# Altium schematic sheet 2- balenaFin HAT SCH.SchDoc
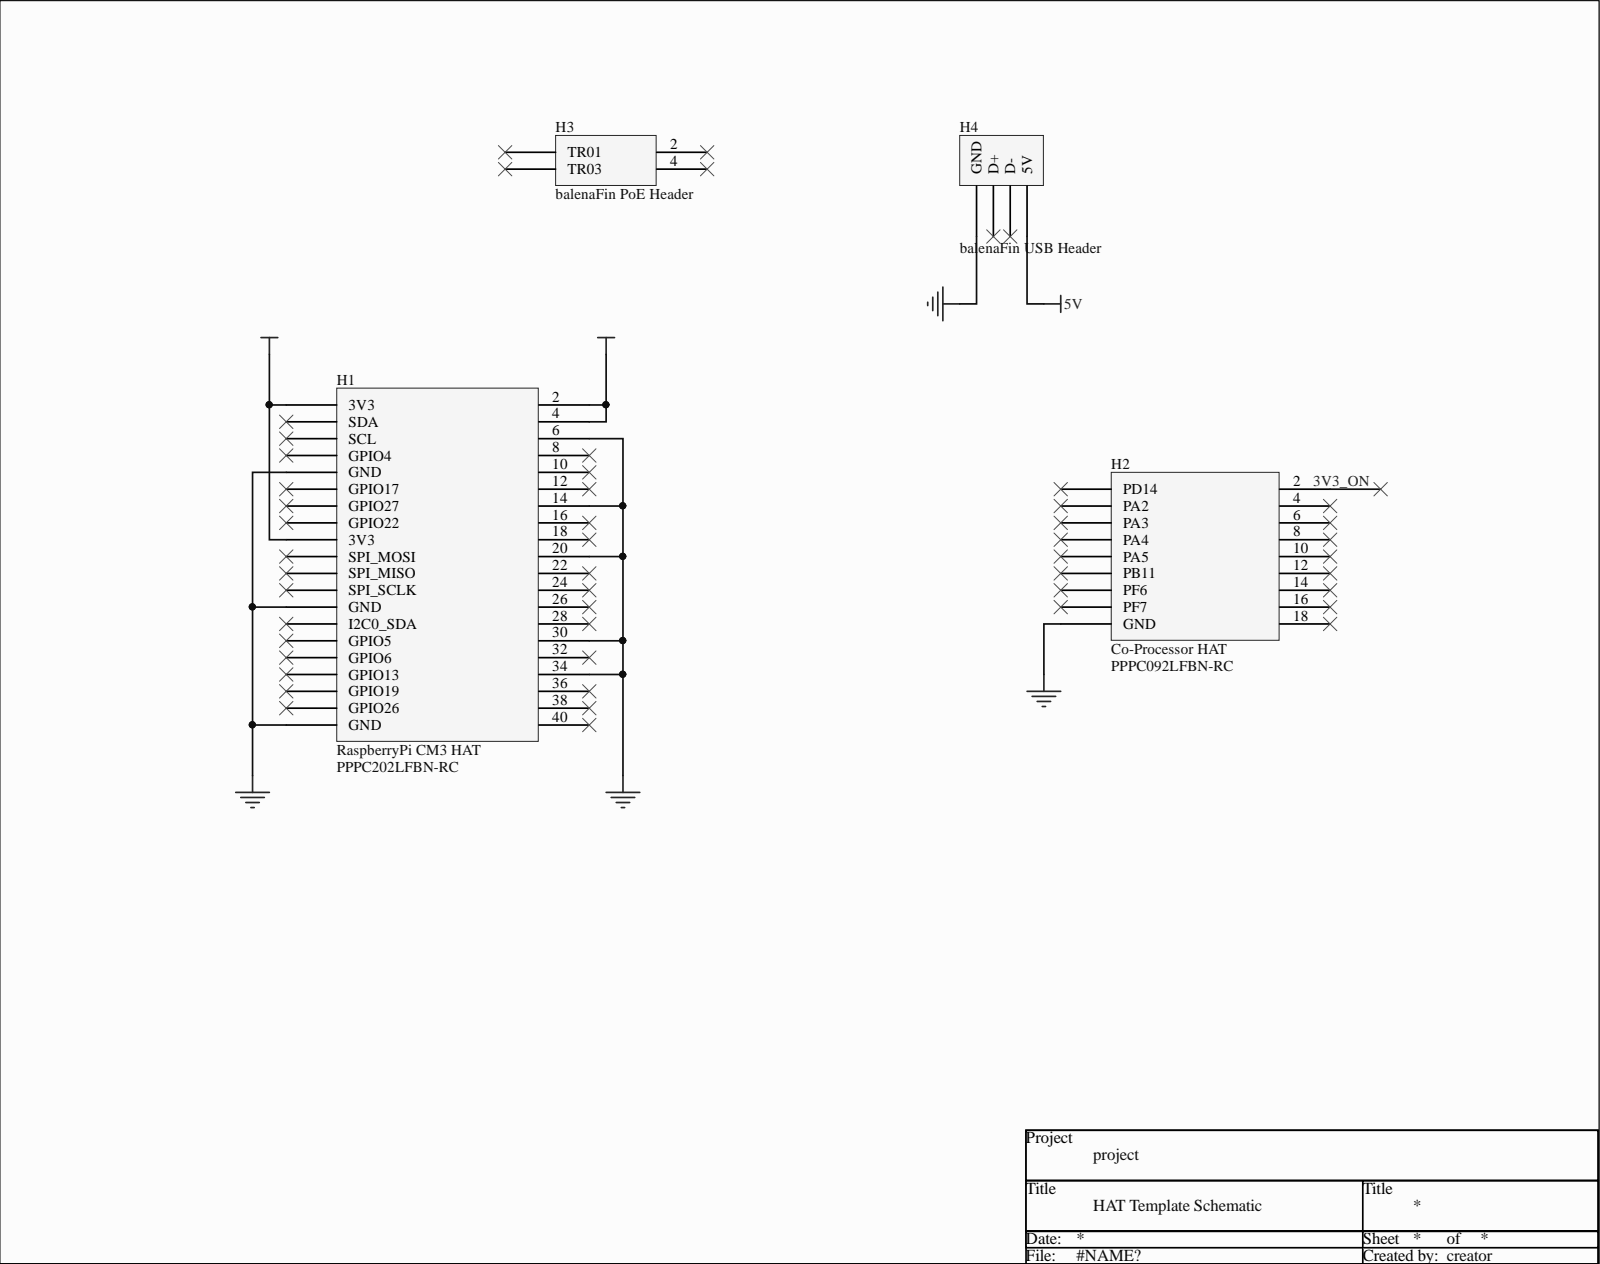
[diagram p0: 2- balenaFin HAT SCH.SchDoc]

## Parts
H1  RaspberryPi CM3 HAT  Manufacturer Part Number: PPPC202LFBN-RC  [HDR2x20_2mm54]
H2  Co-Processor HAT  Manufacturer Part Number: PPPC092LFBN-RC  [HDR2x9_2mm54]
H3  balenaFin PoE Header  [HDR2x2_2mm54]
H4  balenaFin USB Header  [HDR1x4_2mm54]

## Nets
3V3: H1.1(3V3) H1.17(3V3)
5V: H1.2 H1.4 H4.1(5V)
GND: H1.6 H1.9(GND) H1.14 H1.20 H1.25(GND) H1.30 H1.34 H1.39(GND) H2.17(GND) H4.4(GND)
3V3_ON:

Not connected: H1 3(SDA) 5(SCL) 7(GPIO4) 8 10 11(GPIO17) 12 13(GPIO27) 15(GPIO22) 16 18 19(SPI_MOSI) 21(SPI_MISO) 22 23(SPI_SCLK) 24 26 27(I2C0_SDA) 28 29(GPIO5) 31(GPIO6) 32 33(GPIO13) 35(GPIO19) 36 37(GPIO26) 38 40; H2 1(PD14) 2 3(PA2) 4 5(PA3) 6 7(PA4) 8 9(PA5) 10 11(PB11) 12 13(PF6) 14 15(PF7) 16 18; H3 1(TR01) 2 3(TR03) 4; H4 2(D-) 3(D+)

## Library data of the parts
H1 = CM3_Header: Raspberry Pi CM3 HAT Socket; Manufacturer: Sullins; Connector Type: Header,Socket; Number of Positions: 40; Pitch: 0.1inch; Color: Black; Component Kind: Standard; Contact Material: Bronze; Contact Plating: Gold; Height: 8.5mm; Housing Material: Plastic; Lead Free: Lead Free; Length: 51.3mm; Mount: Through Hole; Number of Rows: 2; Package Quantity: 1000; Packaging: Bulk; Row Spacing: 0.1inch; Stock 1: 0; Supplier 1: Future Electronics; Supplier Part Number 1: PPPC202LFBN-RC; Termination: Solder; Width: 5mm; Library Name: balenaPerf schlib.SchLib
H2 = COP_Header: balenaFin Co-Processor HAT Socket; Manufacturer: Sullins; Connector Type: Header,Socket; Number of Positions: 18; Pitch: 0.1inch; Color: Black; Component Kind: Standard; Contact Material: Bronze; Contact Plating: Gold; Height: 8.5mm; Housing Material: Plastic; Lead Free: Lead Free; Length: 23.4mm; Mount: Through Hole; Number of Rows: 2; Package Quantity: 1000; Packaging: Bulk; Row Spacing: 0.1inch; Stock 1: 0; Supplier 1: Future Electronics; Supplier Part Number 1: PPPC092LFBN-RC; Termination: Solder; Width: 5mm; Library Name: balenaPerf schlib.SchLib
H3 = POE_Header: Manufacturer: Sullins; Manufacturer Part Number: PPPC022LFBN-RC; Connector Type: Header,Socket; Number of Positions: 4; Pitch: 0.1inch; Color: Black; Component Kind: Standard; Contact Material: Bronze; Contact Plating: Gold; Description: balenaFin PoE Header; Height: 8.5mm; Housing Material: Plastic; Lead Free: Lead Free; Length: 5.58mm; Mount: Through Hole; Number of Rows: 2; Package Quantity: 1000; Packaging: Bulk; Row Spacing: 0.1inch; Stock 1: 0; Supplier 1: Future Electronics; Supplier Part Number 1: PPPC022LFBN-RC; Termination: Solder; Width: 5mm; Library Name: balenaPerf schlib.SchLib
H4 = USB_Header: Manufacturer: Sullins; Manufacturer Part Number: PPPC041LFBN-RC; Connector Type: Header,Socket; Number of Positions: 4; Pitch: 0.1inch; Color: Black; Component Kind: Standard; Contact Material: Bronze; Contact Plating: Gold; Description: balenaFin USB Header; Height: 8.5mm; Housing Material: Plastic; Lead Free: Lead Free; Length: 10.7mm; Mount: Through Hole; Number of Rows: 1; Package Quantity: 1000; Packaging: Bulk; Stock 1: 0; Supplier 1: Future Electronics; Supplier Part Number 1: 6009019; Termination: Solder; Width: 2.5mm; Library Name: balenaPerf schlib.SchLib
